# Altium schematic sheet remoter.SchDoc
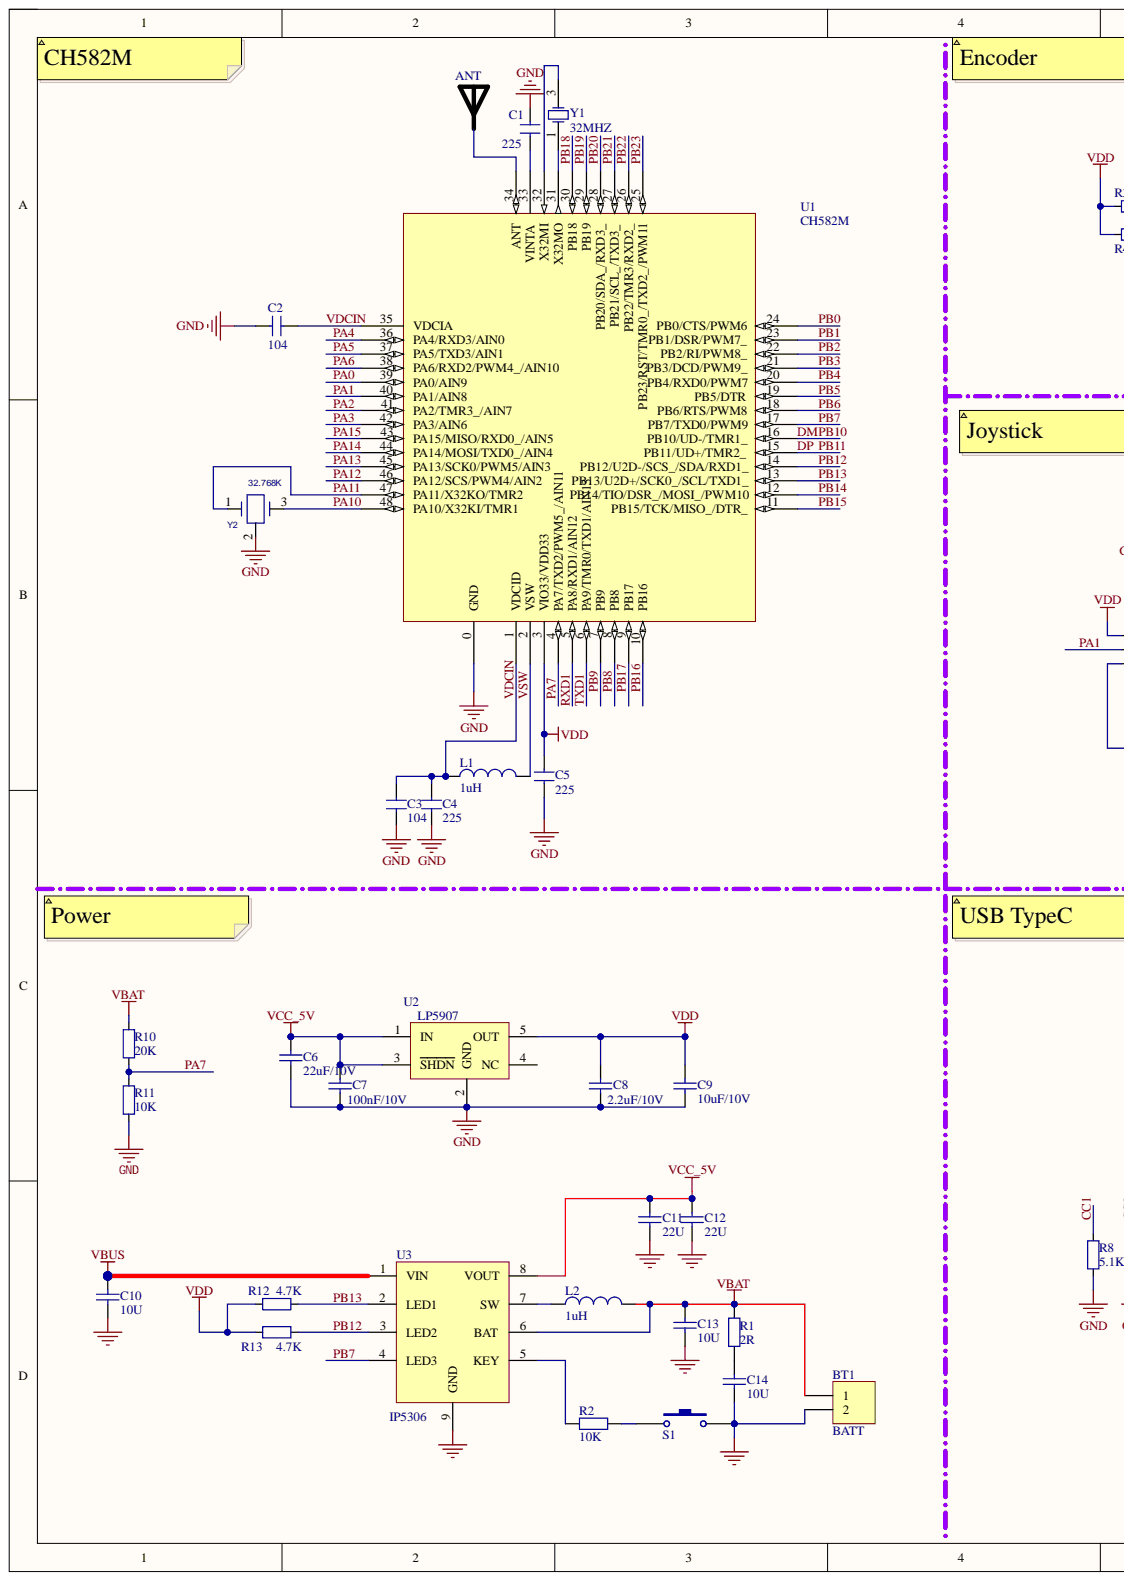
[diagram p0: remoter.SchDoc, left]
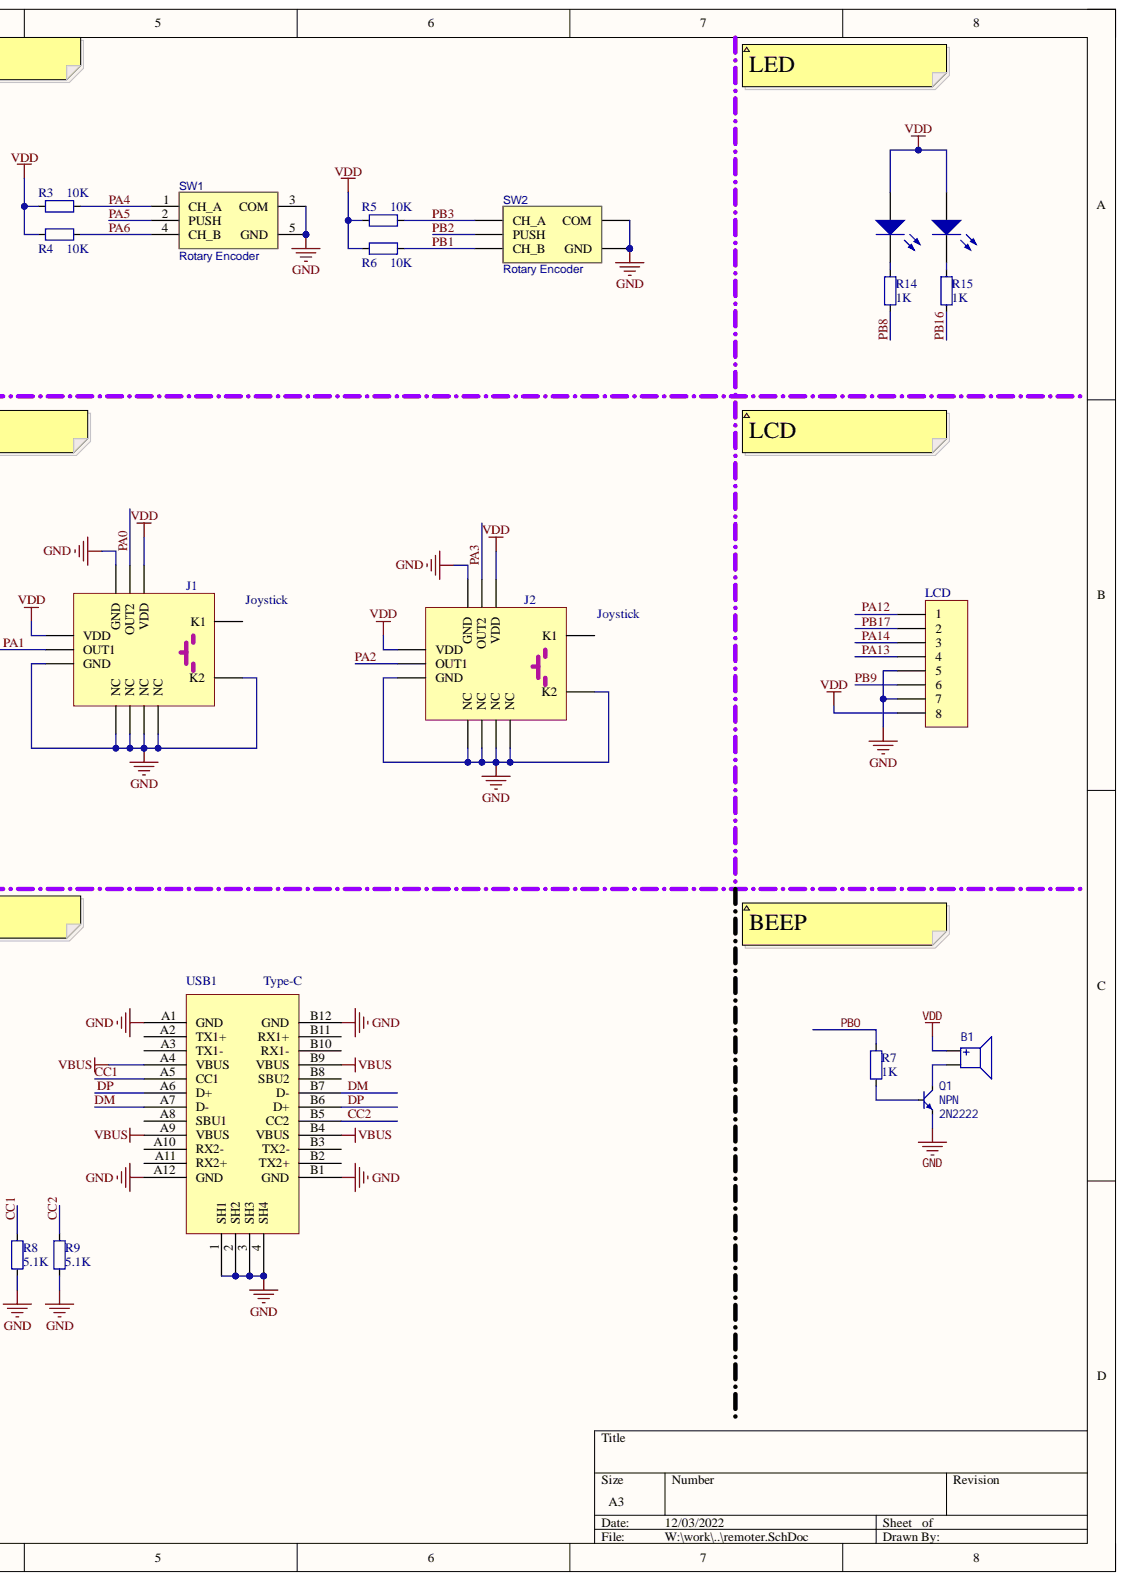
[diagram p1: remoter.SchDoc, right]

## Parts
ANT  RAINSUN  [ANT-Rainsun-AN5120]  (value not drawn)
B1  Buzzer  [Buzzer_5.0x5.0x2.0]  (value not drawn)
BT1  BATT  [HEADER_1X4_T]
C1  225  [CAP_0402]
C2  104  [CAP_0402]
C3  104  [CAP_0402]
C4  225  [0603-CAP]
C5  225  [0603-CAP]
C6  22uF/10V  [C_0805]
C7  100nF/10V  [CAP_0402]
C8  2.2uF/10V  [C_0805]
C9  10uF/10V  [0603-CAP]
C10  value 10U  [0603-CAP]  (hidden comment: 104)
C11  22U  [C_0805]
C12  22U  [C_0805]
C13  value 10U  [C_0805]  (hidden comment: 104)
C14  value 10U  [C_0805]  (hidden comment: 105)
D1  LED-R  [D_0402_Led_green]  (value not drawn)
D2  50%  [D_0402_Led_green]  (value not drawn)
J1  Joystick  [PS_Joystick]
J2  Joystick  [PS_Joystick]
L1  1uH  [SWPA252010S2R2MT]
L2  1uH  [IND_LQH44PZ100MGRL]
LCD  FPC1.0-8PIN  [686108148922]  (value not drawn)
Q1  2N2222  Note: NPN  [SOT23-3]
R1  2R  [RES_0402]
R2  value 10K  [RES_0402]  (hidden comment: 103)
R3  10K  [RES_0402]
R4  10K  [RES_0402]
R5  10K  [RES_0402]
R6  10K  [RES_0402]
R7  1K  [RES_0402]
R8  5.1K  [RES_0402]
R9  5.1K  [RES_0402]
R10  20K  [RES_0402]
R11  10K  [RES_0402]
R12  4.7K  [RES_0402]
R13  4.7K  [RES_0402]
R14  1K  [RES_0402]
R15  1K  [RES_0402]
S1  (no value)  [ButtonTACT_3x4x2_180]
SW1  Rotary Encoder  [SIQ-02FVS3]
SW2  Rotary Encoder  [SIQ-02FVS3]
U1  CH582M  [QFN48_5X5]
U2  LP5907  [SOT23-5]
U3  IP5306  [SOP-8 带散热]
USB1  Type-C  [USB Type-C-16Pin_A]
Y1  32MHZ  [SMD-3225_4P]
Y2  32.768K  [murata_cstce8m00g15c99-r0]

## Nets
GND: BT1.2 C1.1 C2.1 C3.1 C4.1 C5.1 C6.2 C7.1 C8.1 C9.2 C10.1 C11.1 C12.1 C13.1 C14.1 J1.1(GND) J1.4(GND) J1.10(K2) J1.11(NC) J1.12(NC) J1.13(NC) J1.14(NC) J2.1(GND) J2.4(GND) J2.10(K2) J2.11(NC) J2.12(NC) J2.13(NC) J2.14(NC) LCD.5 LCD.7 Q1.2 R8.2 R9.2 R11.2 S1.2 SW1.3(COM) SW1.5(GND) SW2.3(COM) SW2.5(GND) U1.0(GND) U2.2(GND) U3.9(GND) USB1.1(SH1) USB1.2(SH2) USB1.3(SH3) USB1.4(SH4) USB1.A1(GND) USB1.A12(GND) USB1.B1(GND) USB1.B12(GND) Y2.2
VBAT: BT1.1 C13.2 L2.2 R1.1 R10.1 U3.6(BAT)
VBUS: C10.2 U3.1(VIN) USB1.A4(VBUS) USB1.A9(VBUS) USB1.B4(VBUS) USB1.B9(VBUS)
VCC_5V: C6.1 C7.2 C11.2 C12.2 U2.1(IN) U2.3(SHDN) U3.8(VOUT)
VDD: B1.P C5.2 C8.2 C9.1 D1.1 D2.1 J1.3(VDD) J1.6(VDD) J2.3(VDD) J2.6(VDD) LCD.8 R3.2 R4.2 R5.2 R6.2 R12.1 R13.1 U1.3(VIO33/VDD33) U2.5(OUT)
CC1: R8.1 USB1.A5(CC1)
CC2: R9.1 USB1.B5(CC2)
DM: U1.16(PB10/UD-/TMR1_) USB1.A7(D-) USB1.B7(D-)
DP: U1.15(PB11/UD+/TMR2_) USB1.A6(D+) USB1.B6(D+)
PA0: J1.5(OUT2) U1.39(PA0/AIN9)
PA1: J1.2(OUT1) U1.40(PA1/AIN8)
PA2: J2.2(OUT1) U1.41(PA2/TMR3_/AIN7)
PA3: J2.5(OUT2) U1.42(PA3/AIN6)
PA4: R3.1 SW1.1(CH_A) U1.36(PA4/RXD3/AIN0)
PA5: SW1.2(PUSH) U1.37(PA5/TXD3/AIN1)
PA6: R4.1 SW1.4(CH_B) U1.38(PA6/RXD2/PWM4_/AIN10)
PA7: R10.2 R11.1 U1.4(PA7/TXD2/PWM5_/AIN11)
PA10: U1.48(PA10/X32KI/TMR1) Y2.3
PA11: U1.47(PA11/X32KO/TMR2) Y2.1
PA12: LCD.1 U1.46(PA12/SCS/PWM4/AIN2)
PA13: LCD.4 U1.45(PA13/SCK0/PWM5/AIN3)
PA14: LCD.3 U1.44(PA14/MOSI/TXD0_/AIN4)
PA15: U1.43(PA15/MISO/RXD0_/AIN5)
PB0: R7.1 U1.24(PB0/CTS/PWM6)
PB1: R6.1 SW2.4(CH_B) U1.23(PB1/DSR/PWM7_)
PB2: SW2.2(PUSH) U1.22(PB2/RI/PWM8_)
PB3: R5.1 SW2.1(CH_A) U1.21(PB3/DCD/PWM9_)
PB4: U1.20(PB4/RXD0/PWM7)
PB5: U1.19(PB5/DTR)
PB6: U1.18(PB6/RTS/PWM8)
PB7: U1.17(PB7/TXD0/PWM9) U3.4(LED3)
PB8: R14.1 U1.8(PB8)
PB9: LCD.6 U1.7(PB9)
PB12: R13.2 U1.14(PB12/U2D-/SCS_/SDA/RXD1_) U3.3(LED2)
PB13: R12.2 U1.13(PB13/U2D+/SCK0_/SCL/TXD1_) U3.2(LED1)
PB14: U1.12(PB14/TIO/DSR_/MOSI_/PWM10)
PB15: U1.11(PB15/TCK/MISO_/DTR_)
PB16: R15.1 U1.10(PB16)
PB17: LCD.2 U1.9(PB17)
PB18: U1.30(PB18)
PB19: U1.29(PB19)
PB20: U1.28(PB20/SDA_/RXD3_)
PB21: U1.27(PB21/SCL_/TXD3_)
PB22: U1.26(PB22/TMR3/RXD2_)
PB23: U1.25(PB23/RST/TMR0_/TXD2_/PWM11)
RXD1: U1.5(PA8/RXD1/AIN12)
TXD1: U1.6(PA9/TMR0/TXD1/AIN13)
VDCIN: C2.2 C3.2 C4.2 L1.1 U1.1(VDCID) U1.35(VDCIA)
VSW: L1.2 U1.2(VSW)
NetANT_1: ANT.1 U1.34(ANT)
NetB1_N: B1.N Q1.3
NetC1_2: C1.2 U1.33(VINTA)
NetC14_2: C14.2 R1.2
NetD1_2: D1.2 R14.2
NetD2_2: D2.2 R15.2
NetL2_1: L2.1 U3.7(SW)
NetQ1_1: Q1.1 R7.2
NetR2_1: R2.1 S1.1
NetR2_2: R2.2 U3.5(KEY)
NetU1_31: U1.31(X32MO) Y1.1
NetU1_32: U1.32(X32MI) Y1.3

Not connected: J1 7(K1); J2 7(K1); U2 4(NC); USB1 A2(TX1+) A3(TX1-) A8(SBU1) A10(RX2-) A11(RX2+) B2(TX2+) B3(TX2-) B8(SBU2) B10(RX1-) B11(RX1+)

## Library data of the parts
ANT = ANT-Rainsun-AP5120: Component Kind: Standard; Library Name: MyPcbLib.IntLib
B1 = Buzzer_5x5: Specification: 5.0x5.0mm; Component Kind: Standard; Description: Buzzer; Library Name: SCH_Sound.SchLib; Type: SMD3
BT1 = Header 2H: Header, 2-Pin, Right Angle; Component Kind: Standard; LatestRevisionDate: 17-Jul-2002; LatestRevisionNote: Re-released for DXP Platform.; Library Name: Miscellaneous Connectors.IntLib; Signal Integrity: Connector; Publisher: Altium Limited
C1-C5 = CAP: Component Kind: Standard
C6 C9-C14 = Cap: Capacitor; Component Kind: Standard; LatestRevisionDate: 17-Jul-2002; LatestRevisionNote: Re-released for DXP Platform.; Library Name: Miscellaneous Devices.IntLib; PackageReference: RAD-0.3; Published: 8-Jun-2000; Signal Integrity: Cap; Simulation: CAP; Publisher: Altium Limited
  C6 C9: PCB3D: 0402_C
  C11 C12: Value: 22U
C7 C8 = Cap: Capacitor; Value: 100nF; Component Kind: Standard; LatestRevisionDate: 17-Jul-2002; LatestRevisionNote: Re-released for DXP Platform.; Library Name: Miscellaneous Devices.IntLib; PackageReference: RAD-0.3; PCB3D: 0402_C; Published: 8-Jun-2000; Signal Integrity: Cap; Simulation: CAP; Publisher: Altium Limited
D1 D2 = LED0: Typical INFRARED GaAs LED; Component Kind: Standard; LatestRevisionDate: 08-Jul-2005; LatestRevisionNote: Mech Layer 1 removed.; Library Name: Miscellaneous Devices.IntLib; PackageReference: LED-0; Published: 8-Jun-2000; Simulation: LED0; Publisher: Altium Limited
J1 J2 = ps_joystick: Component Kind: Standard; Library Name: MiniControl.SchLib
L1 L2 = Inductor: Code_IEC: 1005; Code_JEITA: 0402; Component Kind: Standard; Description: Inductor; LatestRevisionDate: 29-May-2009; LatestRevisionNote: IPC-7351 Footprint Added.; Library Name: Miscellaneous Devices.IntLib; PackageDescription: Chip Inductor; PackageReference: 0402-A; Published: 8-Jun-2000; Signal Integrity: Inductor; Simulation: INDUCTOR; Value: 1uH; Publisher: Altium Limited
LCD = Header 8: Header, 8-Pin; Component Kind: Standard; LatestRevisionDate: 17-Jul-2002; LatestRevisionNote: Re-released for DXP Platform.; Library Name: Miscellaneous Connectors.IntLib; Signal Integrity: Connector; Publisher: Altium Limited
Q1 = BJT_NPN: Transistor; Component Kind: Standard; Library Name: SCH_Active.SchLib; Type: SOT23-3; Value: 2N2222
R1 R2 = Res2: Resistor; Component Kind: Standard; LatestRevisionDate: 17-Jul-2002; LatestRevisionNote: Re-released for DXP Platform.; Library Name: Miscellaneous Devices.IntLib; PackageReference: AXIAL-0.4; Published: 8-Jun-2000; Signal Integrity: Res; Simulation: RESISTOR; Publisher: Altium Limited
  R1: Value: 2R
R3-R15 = 电阻-0402: Resistor; Value: 22R; Component Kind: Standard; Library Name: MyPcbLib.IntLib
S1 = SW-PB: Switch; Component Kind: Standard; LatestRevisionDate: 17-Jul-2002; LatestRevisionNote: Re-released for DXP Platform.; Library Name: Miscellaneous Devices.IntLib; PackageReference: SPST-2; Published: 24-Mar-1999; Publisher: Altium Limited
SW1 SW2 = Rotary Encoder: BOM_Manufacturer: ALPSALPINE; BOM_Manufacturer Part: EC11E1534443; Contributor: LCSC; BOM_JLCPCB Part Class: Extended Part; BOM_Supplier: LCSC; BOM_Supplier Part: C1322543; Component Kind: Standard; Library Name: MyPcbLib.IntLib; spicePre: S; spiceSymbolName: EC11E1534443
U1 = CH582M: RISC-V MCU with BLE/2*USB/ADC/PWM/SPI/I2C/4*UART; Component Kind: Standard; Library Name: CHXXX.schlib
U2 = LTC1844: Component Kind: Standard; PCB3D: SOT23-5
USB1 = USB-Type-C-TOP: Component Kind: Standard; Library Name: MyPcbLib.IntLib
Y1 = SMD-3225_4P  25MHZ 12PF 10PPM: 贴片晶振; Component Kind: Standard; ComponentLink1Description: 供应商链接; ComponentLink1URL: http://www.szlcsc.com/product/details_9526.html; Library Name: FlightControl.IntLib; Package: SMD-3225_4P; Supplier: LC; SuppliersPartNumber: C9006
Y2 = resonator: CER RES 8.0000MHZ 33PF SMD; Manufacturer: Murata Electronics North America; Manufacturer PN: CSTNE8M00GH5C000R0; PART_NUMBER: TMP-382; CLASS: DISCRETE; Frequency Stability: 0.13%; Frequency Tolerance: 0.1%; Component Kind: Standard; Library Name: MB1419.IntLib; Package Size: 0.126" L x 0.051" W (3.20mm x 1.30mm)
U3 = IP5306: Component Kind: Standard; Library Name: Schlib1.SchLib
